# Altium schematic sheet 05021002_PWM - Buzzer and Led.SchDoc("05021002_PWM - Buzzer and Led")
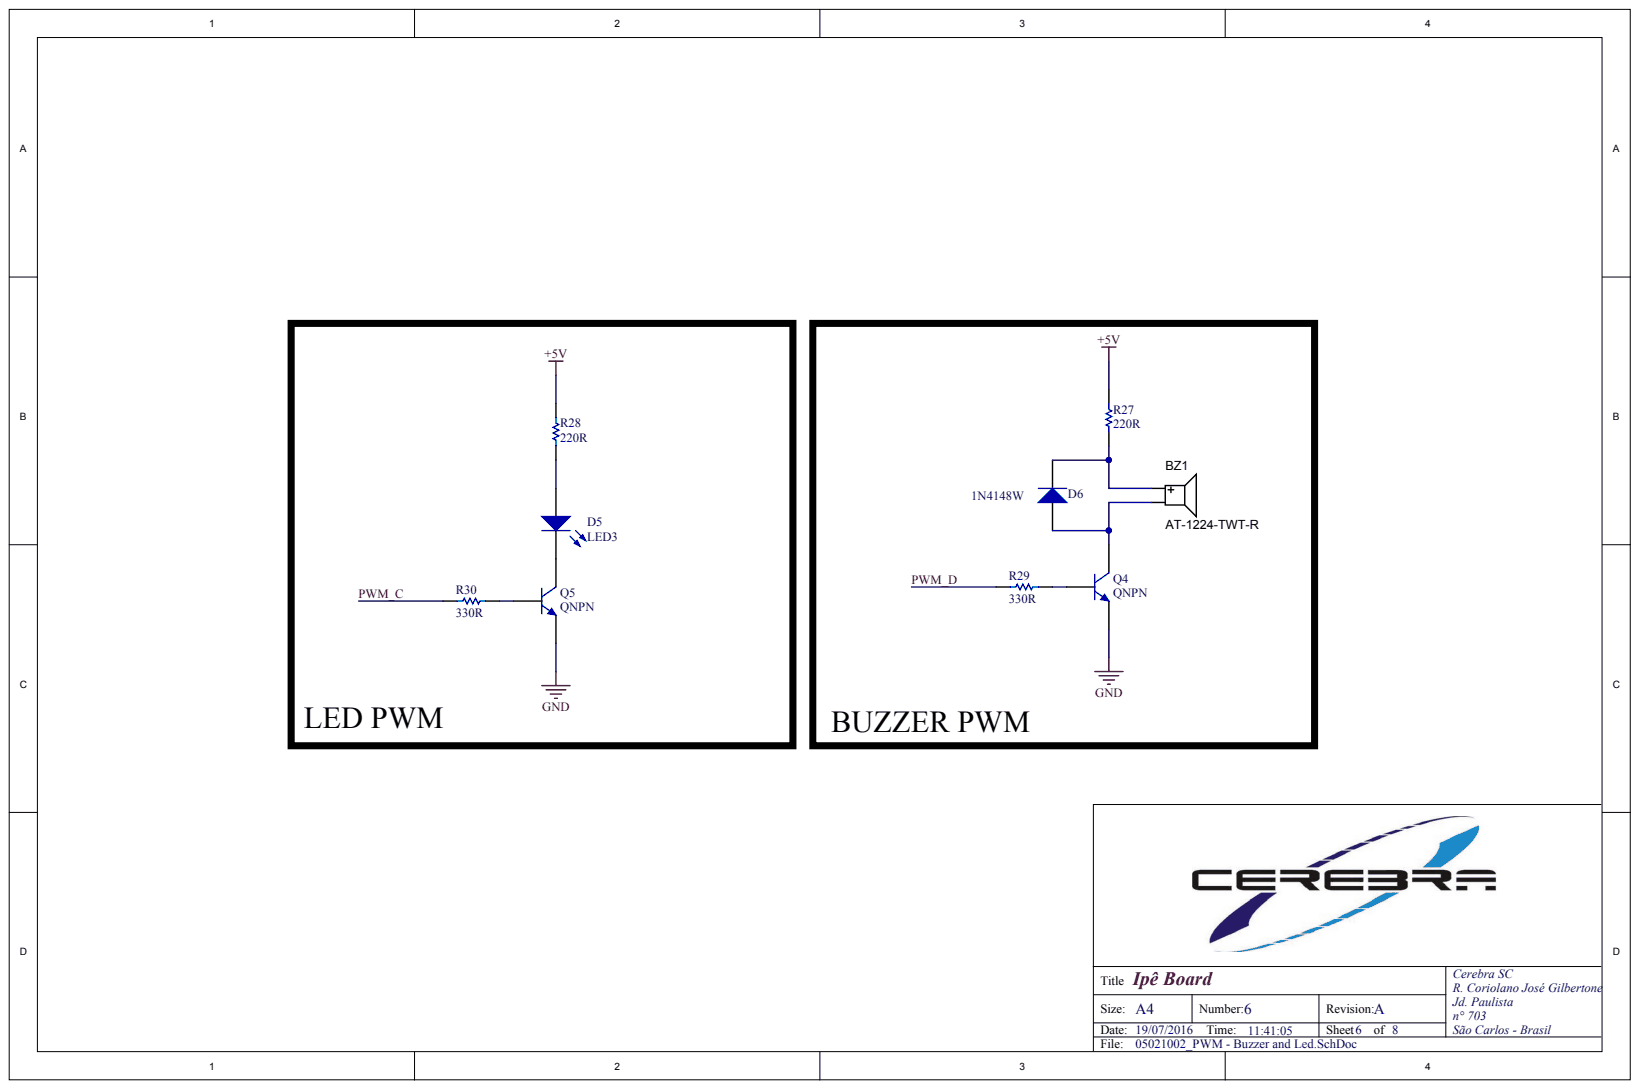
[diagram p0: 05021002_PWM - Buzzer and Led.SchDoc]

## Parts
BZ1  Part Number 1: AT-1224-TWT-R  [BUZZER_12.0X8.5MM]  (hidden comment: 5V BUZZER)
D5  LED3  [LED-0805-K]
D6  1N4148W  [SOD-123]
Q4  QNPN  [SOT-23]
Q5  QNPN  [SOT-23]
R27  220R  [0805]
R28  220R  [0805]
R29  330R  [0805]
R30  330R  [0805]

## Nets
+5V: R27.2 R28.2
GND: Q4.3 Q5.3
PWM_C: R30.1
PWM_D: R29.1
NetBZ1_1: BZ1.1 D6.1 R27.1
NetBZ1_2: BZ1.2 D6.2 Q4.1
NetD5_1: D5.1 R28.1
NetD5_2: D5.2 Q5.1
NetQ4_2: Q4.2 R29.2
NetQ5_2: Q5.2 R30.2

## Library data of the parts
BZ1 = BZ_12x8.5x6.5_2kHz: Speaker, Magnetic Transducer; Manufacturer 1: PUI Audio; SCDType: source; Component Kind: Standard; Library Name: PBL - Electromechanical.SCHLIB; Package / Case: 6.5mm pitch
D5 = LED3: Typical BLUE SiC LED; Manufacturer 1: OSRAM Opto Semiconductors Inc.; Part Number 1: LG R971-KN-1; Component Kind: Standard; DatasheetVersion: 16-Apr-2002; LatestRevisionDate: 17-Dec-2003; LatestRevisionNote: PCB Footprint replaced.; Library Name: Miscellaneous Devices.IntLib; PackageDescription: SM LED; 2 C-Bend Leads; Body 3.5 x 2.8 x 1.9 mm, inc leads (LxWxH typ); PackageReference: 3.5X2.8X1.9; PackageVersion: 16-Apr-2002; Published: 8-Jun-2000; Signal Integrity: LED; Simulation: LED3; Publisher: Altium Limited
D6 = 1N4148W: Small Signal Fast Switching Diode; Manufacturer 1: Micro Commercial Co; Part Number 1: 1N4148W-TP; Component Kind: Standard; Library Name: PROJ_SENSOMASTHER.IntLib
Q4 Q5 = QNPN: NPN Bipolar Transistor; Manufacturer 1: Diodes Incorporated; Part Number 1: MMBT2222A-7-F; Component Kind: Standard; LatestRevisionDate: 29-May-2009; LatestRevisionNote: IPC-7351 Footprint Added.; Library Name: Miscellaneous Devices.IntLib; PackageDescription: 3-Pin SOT-23 Package 0.95 mm Pitch, 2.4 mm Lead Span; PackageReference: SOT-23B; PackageVersion: Aug-1999; Published: 8-Jun-2000; Signal Integrity: NPN; Simulation: QNPN; Publisher: Altium Limited
R27 R28 = resistor_gen: Manufacturer 1: Stackpole Electronics Inc.; Part Number 1: RMCF0805JT220R; Component Kind: Standard; Library Name: resistor_smd_gen.SchLib; Value: 220R
R29 R30 = resistor_gen: Manufacturer 1: Stackpole Electronics Inc.; Part Number 1: RMCF0805JT330R; Component Kind: Standard; Library Name: resistor_smd_gen.SchLib; Value: 330R
